# Altium schematic sheet SmartSwitch P1.SchDoc
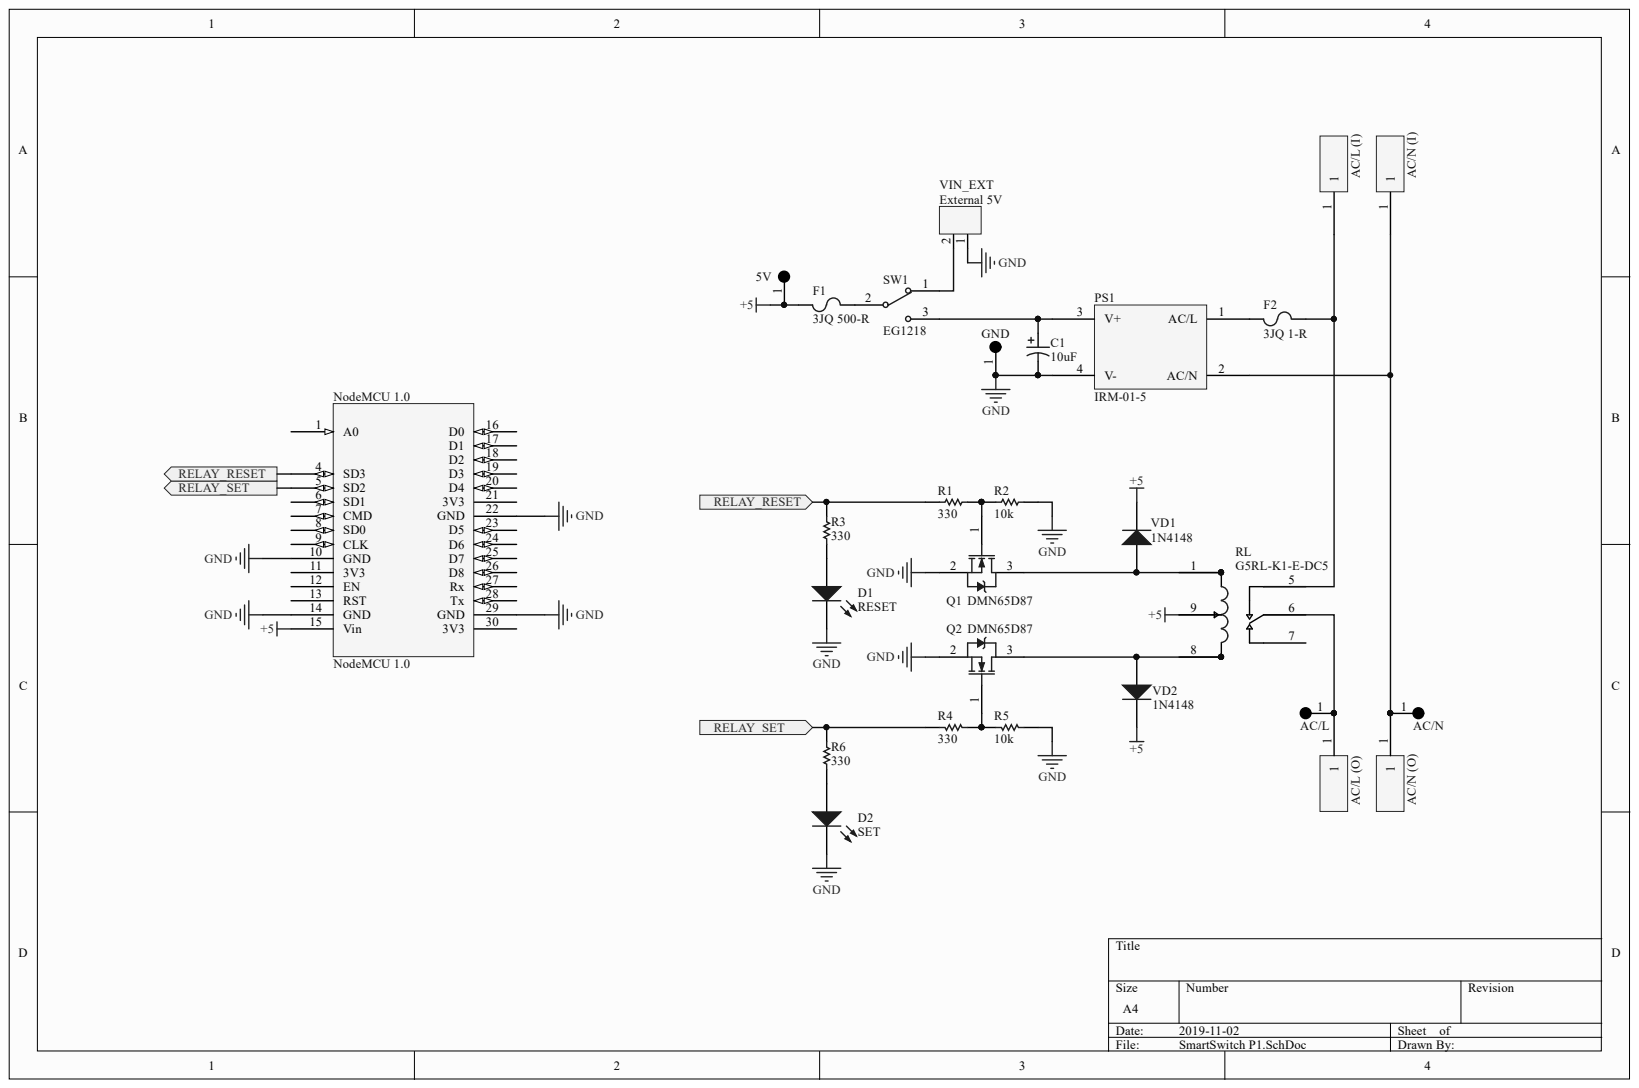
[diagram p0: SmartSwitch P1.SchDoc]

## Parts
5V  Keystone 5011  [PCB-nje03k9v44gt2snsz3w9-1]  (value not drawn)
AC/L  Keystone 5011  [PCB-nje03k9v44gt2snsz3w9-1]  (value not drawn)
AC/L_(I)  Würth Elektronik 691213610001  [PCB-9ig08nj09kvc8fg5cbvo-1]  (value not drawn)
AC/L_(O)  Würth Elektronik 691213610001  [PCB-9ig08nj09kvc8fg5cbvo-1]  (value not drawn)
AC/N  Keystone 5011  [PCB-nje03k9v44gt2snsz3w9-1]  (value not drawn)
AC/N_(I)  Würth Elektronik 691213610001  [PCB-9ig08nj09kvc8fg5cbvo-1]  (value not drawn)
AC/N_(O)  Würth Elektronik 691213610001  [PCB-9ig08nj09kvc8fg5cbvo-1]  (value not drawn)
C1  10uF  [CAPAE4643X61M]
D1  RESET  [PCB-qe300kdc9tuz1owjehq9-1]
D2  SET  [PCB-qe300kdc9tuz1owjehq9-1]
F1  3JQ 500-R  [PCB-vxippmkvw2szlmf2btqj-1]
F2  3JQ 1-R  [PCB-ytctgmw176utm1gehaug-1]
GND  Keystone 5011  [PCB-nje03k9v44gt2snsz3w9-1]  (value not drawn)
NodeMCU_1.0  NodeMCU 1.0  [PCB-4f2jj0tqcwafqtaaud9f-1]
PS1  IRM-01-5  [PCB-9z1y1qd629hvy7vu8n4b-1]
Q1  DMN65D87  [PCB-nw73lpgy3xhhfuwv1f85-1]
Q2  DMN65D87  [PCB-nw73lpgy3xhhfuwv1f85-1]
R1  330  [RESC1608X06M]
R2  10k  [RESC1608X06M]
R3  330  [RESC1608X06M]
R4  330  [RESC1608X06M]
R5  10k  [RESC1608X06M]
R6  330  [RESC1608X06M]
RL  G5RL-K1-E-DC5  [PCB-yec2uco0ixtl2yrw8m1g-1]
SW1  EG1218  [ESWI-EG1218_V]
VD1  1N4148  [PCB-2bm24o7p3s75zc4txknh-1]
VD2  1N4148  [PCB-2bm24o7p3s75zc4txknh-1]
VIN_EXT  External 5V  [PCB-6xrngco598u3mra2uzi2-1]

## Ports
RELAY_RESET, RELAY_SET

## Nets
+5: 5V.1 F1.1 NodeMCU_1.0.15(Vin) RL.9 VD1.2 VD2.2
GND: C1.2 D1.2 D2.2 GND.1 NodeMCU_1.0.10(GND) NodeMCU_1.0.14(GND) NodeMCU_1.0.22(GND) NodeMCU_1.0.29(GND) PS1.4(V-) Q1.2 Q2.2 R2.2 R5.2 VIN_EXT.1
RELAY_RESET: NodeMCU_1.0.4(SD3) R1.1 R3.2 ; port RELAY_RESET
RELAY_SET: NodeMCU_1.0.5(SD2) R4.1 R6.2 ; port RELAY_SET
NetAC/L (I)_1: AC/L_(I).1 F2.1 RL.5
NetAC/L (O)_1: AC/L.1 AC/L_(O).1 RL.6
NetAC/N (I)_1: AC/N.1 AC/N_(I).1 AC/N_(O).1 PS1.2(AC/N)
NetC1_1: C1.1 PS1.3(V+) SW1.3
NetD1_1: D1.1 R3.1
NetD2_1: D2.1 R6.1
NetF1_2: F1.2 SW1.2
NetF2_2: F2.2 PS1.1(AC/L)
NetQ1_1: Q1.1 R1.2 R2.1
NetQ1_3: Q1.3 RL.1 VD1.1
NetQ2_1: Q2.1 R4.2 R5.1
NetQ2_3: Q2.3 RL.2 RL.8 VD2.1
NetSW1_1: SW1.1 VIN_EXT.2

Not connected: NodeMCU_1.0 1(A0) 6(SD1) 7(CMD) 8(SD0) 9(CLK) 11(3V3) 12(EN) 13(RST) 16(D0) 17(D1) 18(D2) 19(D3) 20(D4) 21(3V3) 23(D5) 24(D6) 25(D7) 26(D8) 27(Rx) 28(Tx) 30(3V3); RL 7

## Library data of the parts
5V AC/L AC/N GND = 5011: Test Point; Black; Thru-Hole; Snap-Fit; SilverPlate; 0.063in. Dia.; 0.445In.; Component Kind: Net Tie; Library Name: Octopart library
AC/L_(I) AC/L_(O) AC/N_(I) AC/N_(O) = CMP-e2d12f68bdc03d16-1: Serie 2136 - 10.16 mm Horizontal Entry with Rising Cage Clamp WR-TBL, 1 pin; Pin Pitch: 10.2 mm; Component Kind: Standard; Library Name: FavoritesLibrary; Manufacturer Part Number: 691213610001; Mounting Style: Through Hole; Number of Pins: 1; OctopartID: e2d12f68bdc03d16; Octopart URL: https://octopart.com/691213610001-w%C3%BCrth+elektronik-78871159; Operating Temperature: 120 °C (max); Packaging: Box
C1 = CMP-571c9c4c020688db-4: Capacitor; Alum Electrolytic; Cap 10 uF; Tol 20%; Vol-Rtg 25 VDC; SMT; 4x5.8; Tape&Reel; Manufacturer Part Number: EEEFK1E100AR; Capacitance: 10.0 µF; Dielectric Material: Aluminum Electrolytic; Lead-Free Status: Lead Free; Voltage Rating (DC): 25.0 V; Capacitance Tolerance: ±20%; Component Kind: Standard; Library Name: CommunityVault; Lifecycle Status: Not Listed by Manufacturer; Mounting Style: Through Hole, Surface Mount, PCB; OctopartID: 862b4f0784ab59ec; Octopart URL: https://octopart.com/eeefk1e100ar-panasonic-2500582; Packaging: Cut Tape (CT), Reel, Tape & Reel (TR); REACH SVHC Compliance: No SVHC; Size-Diameter: 4.00 mm; Size-Height: 5.80 mm; Size-Length: 5.80 mm; Size-Width: 5.50 mm
D1 D2 = HLMP-D150: LED Uni-Color Red 645nm 2-Pin T-1 3/4; Current Rating: 30.0 mA; Lead-Free Status: Lead Free; Lead Length: 25.0 mm; Lens Color: Clear; Lens Type: Diffused; Luminous Intensity: 3.00 mcd; Viewing Angle: 65 °; Wavelength: 637 nm, 626 nm; Component Kind: Standard; Library Name: Octopart library; Manufacturer Part Number: HLMP-D150; Mounting Style: Through Hole, Vertical; OctopartID: 379cfaab4cbae85b; Packaging: Bulk; Peak Wavelength: 645 nm; REACH SVHC Compliance: No SVHC; RoHS: Compliant; Size-Diameter: 5.00 mm; Size-Height: 4.70 mm
F1 = CMP-2a4ce34e958df58b-1: Fuse Subminiature Fast Acting 0.5A 350V Axial 5 X 15mm Glass CE/CSA/UL/cULus; Current Rating: 500 mA; Lead-Free Status: Lead Free; Component Kind: Standard; Library Name: FavoritesLibrary; Manufacturer Part Number: 3JQ 500-R; OctopartID: 2a4ce34e958df58b; Octopart URL: https://octopart.com/3jq+500-r-bel+fuse-563879; Operating Temperature: 125 °C (max); Packaging: Bulk; RoHS: Compliant; Size-Diameter: 5.50 mm; Size-Length: 15.0 mm; Voltage Rating (DC): 350 V
F2 = CMP-72fabbf8cd1bad3d-1: Fuse Subminiature Fast Acting 1A 350V Axial 5 X 15mm Glass CE/CSA/PSE/UL/cULus; Current Rating: 1.00 A; Lead-Free Status: Lead Free; Component Kind: Standard; Library Name: FavoritesLibrary; Manufacturer Part Number: 3JQ 1-R; OctopartID: 72fabbf8cd1bad3d; Octopart URL: https://octopart.com/3jq+1-r-bel+fuse-563847; Operating Temperature: 125 °C (max); Packaging: Bulk; RoHS: Compliant; Size-Diameter: 5.50 mm; Size-Length: 15.0 mm; Voltage Rating (DC): 350 V
NodeMCU_1.0 = CMP-46578cb04ca89990-2: Nodemcu V2 Lua Based ESP8266 Dev; Manufacturer Part Number: 113990105; Component Kind: Standard; Library Name: FavoritesLibrary; OctopartID: 46578cb04ca89990; Octopart URL: https://octopart.com/113990105-seeed+studio-65875865
PS1 = CMP-ypu2c5gq6vpv0iibqbva-3: AC/DC CONVERTER 5V 1W; Component Kind: Standard; CustomComponentID: ypu2c5gq6vpv0iibqbva; Library Name: FavoritesLibrary
Q1 Q2 = DMN65D8L-7: Trans MOSFET N-CH 60V 0.31A Automotive 3-Pin SOT-23 T/R; Lead-Free Status: Lead Free; Component Kind: Standard; Library Name: Octopart library; Package / Case: TO-236-3, SC-59, SOT-23-3
R1 R3 R4 R6 = CMP-1846135-10: Precision resistor, SMD [Panasonic Automotive & Industrial Systems] ERJ3EKF3300V Precision resistor, SMD; Component Kind: Standard; Library Name: CommunityVault
R2 R5 = CMP-1846091-22: Resistor Thick Film 0603 10k Ohm 1% 1/10 Watt ±100ppm °C Surface Mount Punched Carrier Tape and Reel; Manufacturer Part Number: ERJ-3EKF1002V; Case/Package (SI): 1608; Power Rating: 100 mW; Resistance: 10.0 kΩ; Voltage Rating (DC): 75.0 V; Case/Package: 0603; Component Kind: Standard; Library Name: CommunityVault; Lifecycle Status: Not Listed by Manufacturer; Mounting Style: Surface Mount; Number of Pins: 2; Octopart URL: http://octopart.com/erj-3ekf1002v-panasonic-55403647; Packaging: Cut Tape (CT), Tape & Reel (TR); REACH SVHC Compliance: No SVHC; Resistance Tolerance: ±1%; RoHS: Compliant; Size-Height: 450 µm; Size-Length: 1.60 mm
RL = CMP-3aa7f14b0c48e560-4: General Purpose Relay, G5rl-K Series, Power, Latching Dual Coil, Spdt, 5 Vdc, 16 A; Component Kind: Standard; Contact Material: Silver; Contacts Type: SPDT; Library Name: FavoritesLibrary; Manufacturer Part Number: G5RL-K1-E-DC5; OctopartID: ac64665b124673a3; Octopart URL: https://octopart.com/g5rl-k1-e-dc5-omron-53844804; REACH SVHC Compliance: No SVHC; RoHS: Compliant
SW1 = EG1218: Slide Switch, 500 V, -20 to 70 degC, 3-Pin THD, RoHS; Actuator Type: Standard; Contacts Type: SPDT; Current Rating: 200 mA; Lead-Free Status: Lead Free; Number of Positions: 2; Component Kind: Standard; Contact Material: Copper Alloy; Contact Plating: Silver; DatasheetVersion: Oct-15; Library Name: Octopart library; Manufacturer Part Number: EG1218; Mounting Style: PCB, Through Hole; OctopartID: 9a5a5340a2f5459d; Octopart Url: http://octopart.com/eg1218-e-switch-1056201; Orientation: Vertical; PackageDescription: 3-Pin Through Hole Device, Body 11.6 x 4 mm, Pitch 2.5 mm; PackageReference: EG1218; Packaging: Bulk; RoHS: Compliant; Seller Landing  Url: https://octopart-clicks.com/click/track?country=US&ak=8157f166&sig=0e9adab&sid=459&ppid=1056201&vpid=1179261&ct=offers; Size-Height: 5.40 mm; Size-Length: 2.03 mm; Size-Width: 4.00 mm
VD1 VD2 = 1N4148W: Diode: switching; SMD; 100V; 0.15A; 4ns; 400mW; Package: reel, tape; Corpus: sod-123; Component Kind: Standard; Library Name: Octopart library; Manufacturer Part Number: 1N4148W; Mounting Style: Surface Mount; OctopartID: 93d6585914c84c11
VIN_EXT = 282834-2: Wire-To-Board Terminal Block, 2, 150 V, 10 A, 30 Awg, 16 Awg, 1.4 Mm; ELV Compliant: Compliant; Lead-Free Status: Lead Free; Number of Contacts: 2; Wire Gauge: 16.0 AWG to 30.0 AWG; Color: Green; Component Kind: Standard; Contact Material: Brass; Contact Plating: Tin; Flammability Rating: UL 94 V-0; Library Name: Octopart library; Mounting Style: Screw, Through Hole; Octopart URL: https://octopart.com/282834-2-te+connectivity-46506870; RoHS: Compliant; Size-Width: 6.50 mm
